# Altium schematic sheet PMON.SchDoc(PMON)
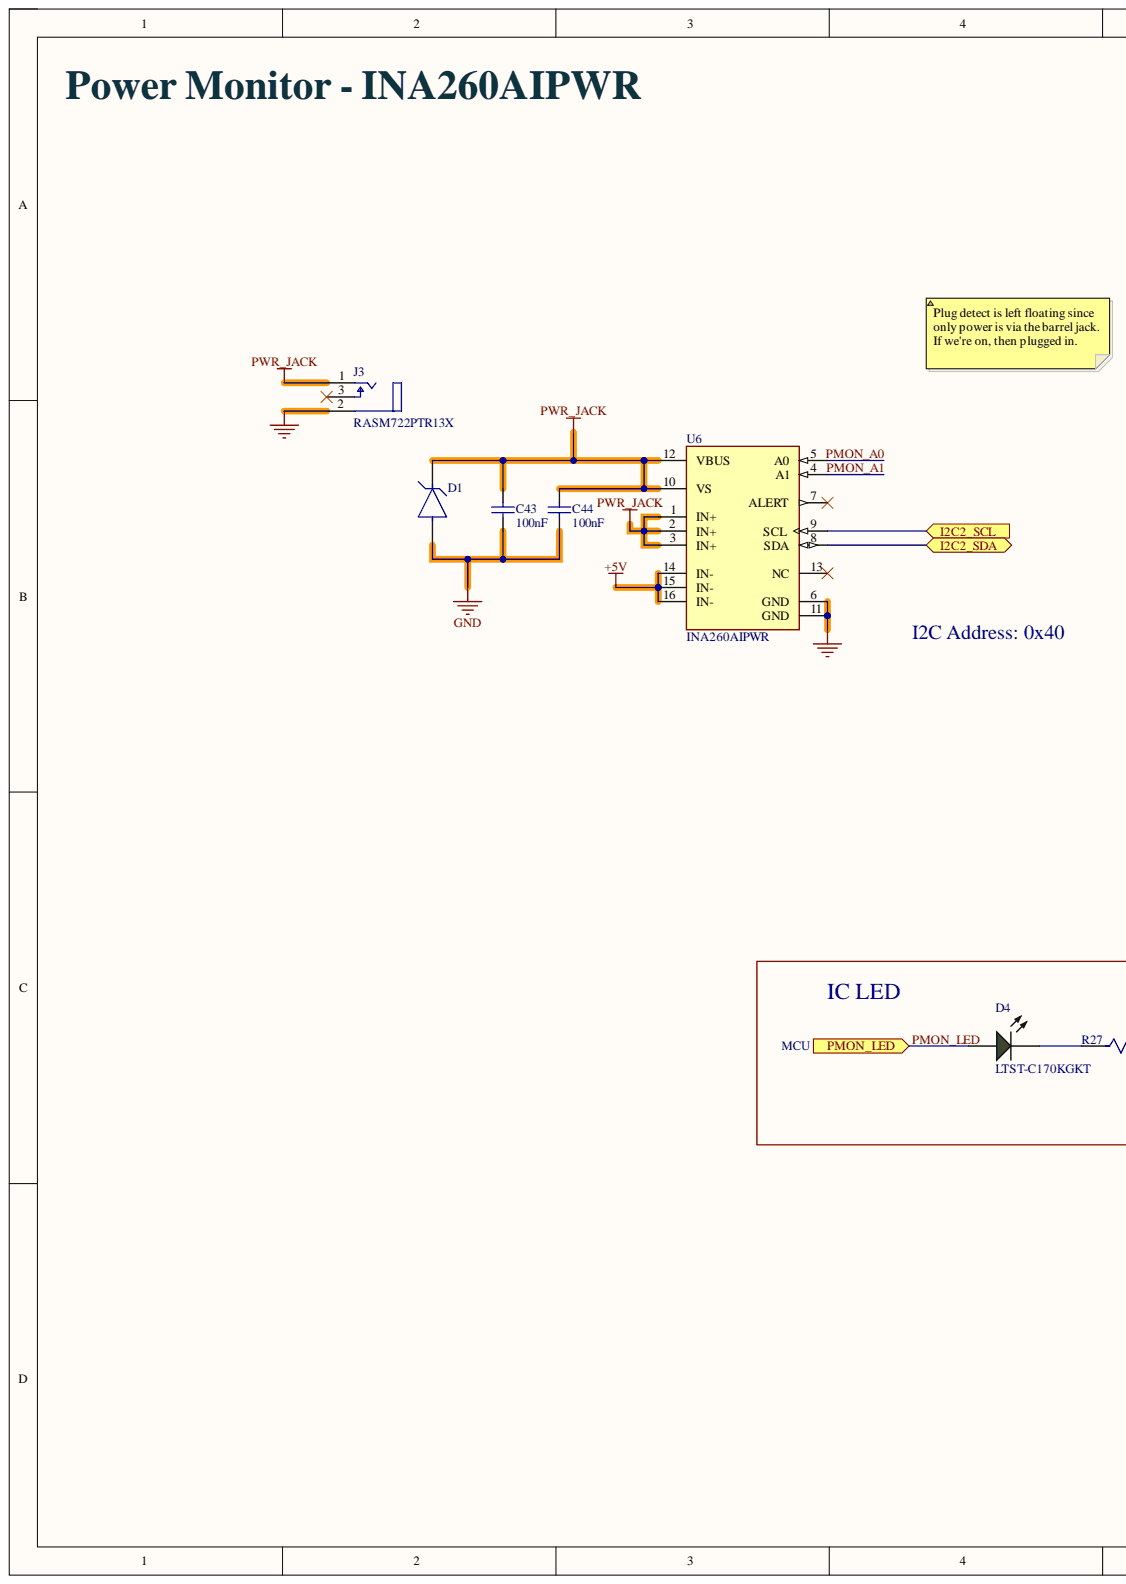
[diagram p0: PMON.SchDoc, left]
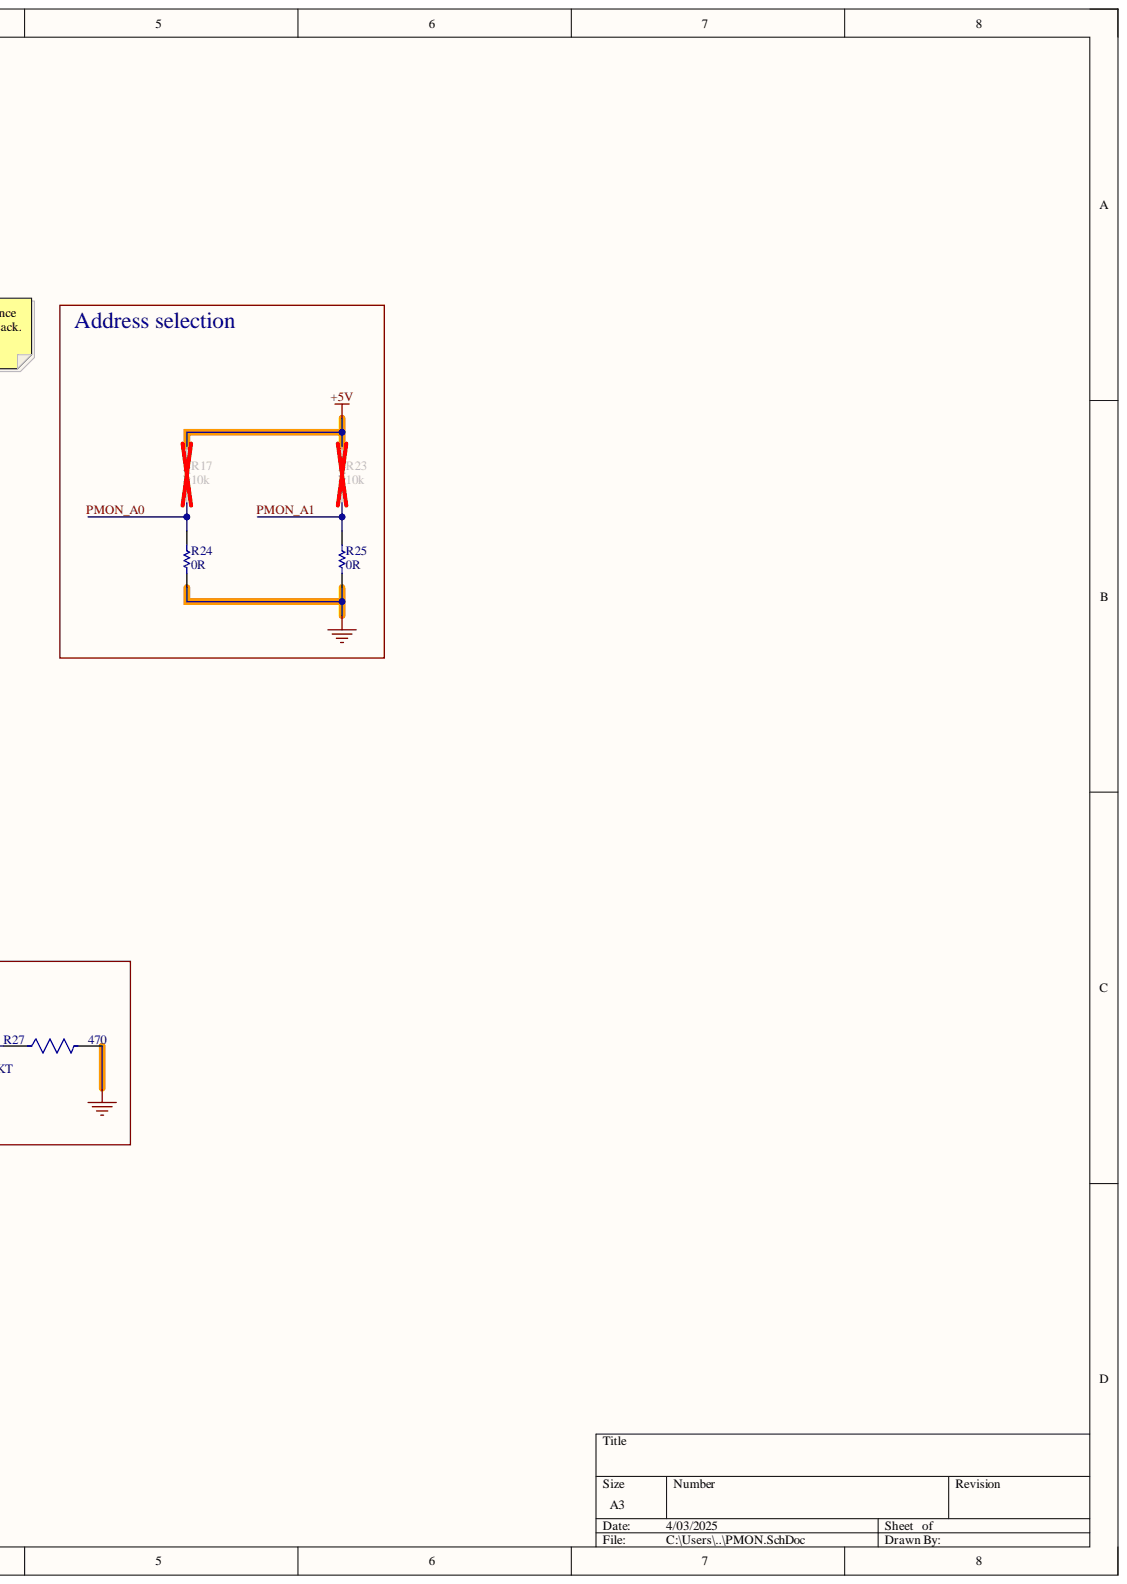
[diagram p1: PMON.SchDoc, right]

## Parts
C43  100nF  [CAPC1005X55X25ML05T10]
C44  100nF  [CAPC1005X55X25ML05T10]
D1  SMBJ5.0A-TR  [FP-SMB-MFG]  (value not drawn)
D4  LTST-C170KGKT  [LTST-LTST-C170KGKT-2_V]
J3  RASM722PTR13X  [FP-RASM722PTR13X-MFG]
R17  10k  [RESC1608X55X25ML10T15]
R23  10k  [RESC1608X55X25ML10T15]
R24  0R  [RESC1608X55X25ML10T15]
R25  0R  [RESC1608X55X25ML10T15]
R27  470  [FP-CPF0603-MFG]
U6  INA260AIPWR  [FP-PW0016A-MFG]

## Ports
I2C2_SCL, I2C2_SDA, PMON_LED

## Nets
+5V: R17.2 R23.2 U6.14(IN-) U6.15(IN-) U6.16(IN-)
GND: C43.1 C44.1 D1.2 J3.2 R24.1 R25.1 R27.2 U6.6(GND) U6.11(GND)
PWR_JACK: C43.2 C44.2 D1.1 J3.1 U6.1(IN+) U6.2(IN+) U6.3(IN+) U6.10(VS) U6.12(VBUS)
I2C2_SCL: U6.9(SCL) ; port I2C2_SCL
I2C2_SDA: U6.8(SDA) ; port I2C2_SDA
PMON_A0: R17.1 R24.2 U6.5(A0)
PMON_A1: R23.1 R25.2 U6.4(A1)
PMON_LED: D4.2 ; port PMON_LED
NetD4_1: D4.1 R27.1

Not connected: J3 3; U6 7(ALERT) 13(NC)

## Library data of the parts
C43 C44 = CMP-2008-04536-1: GRM155R60J104KA01D; Manufacturer: Murata; Component Kind: Standard; Library Name: Altium Content Vault; Part Number: GRM155R60J104KA01D
D1 = CMP-12225-000019-1: TVS DIODE 5V 13.4V SMB; Manufacturer: STMicroelectronics; Breakdown Voltage: 6.4V; Clamping Voltage: 14.4V; Direction: Unidirectional; Max Junction Temp: +150°C; Number Of Channels: 1; Peak Pulse Current: 275A; Peak Pulse Power: 600W; Standoff Height: 0.05mm; Automotive: No; Category: Diode; Component Kind: Standard; ComponentLink2Description: Datasheet; ComponentLink2URL: https://www.st.com/resource/en/datasheet/smbj.pdf; Device Class L1: Circuit Protection; Device Class L2: TVS Diodes; Device Class L3: unset; Digikey Description: TVS DIODE 5V 13.4V SMB; Height: 2.65mm; Lead Free: Yes; Library Name: Altium Content Vault; Manufacturer Part Number: SMBJ5.0A-TR; Mouser Description: SMBJ Series 600 W 5 V Uni Directional SMT TVS Diode - DO-214AA; Octopart: https://octopart.com/smbj5.0a-tr-stmicroelectronics-347079; Package: SMB; Reverse Standoff Voltage: 5V; RoHS: TRUE; Temperature: -55°C to +150°C; Temperature Range High: +150°C; Temperature Range Low: -55°C
D4 = CMP-2000-06620-1: Ultra Bright AlInGaP Chip LED, 75 mW, -55 to 85 degC, 2-Pin SMD (0805), RoHS, Tape and Reel; Manufacturer: Lite-On; Chip Material: AlGaInP; DELETED: Compliant; Dominant Wave Length: 571nm; Emitting Color: YellowGreen; Forward Current: 30mA; Forward Voltage: 2.4V; High Brightness: Yes; Lum Intensity: 35mcd; Number of  Elements: 1; Peak Wavelength: 574nm; Power Dissipation: 75W; Power Dissipation Max: 75mW; Product Depth (mm): 1.25mm; Product Height (mm): 1.1mm; Product Length (mm): 2mm; Rad Hardened: No; Reverse Voltage: 5V; Shape Type: Rectangular; Test Current (It): 20mA; Type: Uni-Color; Viewing Angle: 130deg; Component Kind: Standard; Datasheet URL: http://optoelectronics.liteon.com/upload/download/DS22-2000-073/S_110_LTST-C170KGKT.pdf; Datasheet Version: Rev. G, 06/2005; Forward Current Max: 30mA; Lens Dimensions: 1.4x1.25x0.6mm; Library Name: Altium Content Vault; Luminous Intensity: 35mcd; Main Category: ChipLED; Manufacturer URL: http://www.us.liteon.com/; Mounting: SurfaceMount; Mounting Technology: SurfaceMount; Operating Temp Range: -55Cto85C; Operating Temperature Classification: Industrial; Package Description: 2-Pin Surface Mounted Device, Body 2 x 1.25 mm; Package Reference: LTST-C170KGKT-2; Package Type: ChipLed; Packaging: TapeandReel; Pin Count: 2
J3 = CMP-47995-000002-1: CONN PWR JACK 2.1X5.5MM SOLDER; Current Rating: 5A; Gender: Male; Shielding: Unshielded; Case/Package: SMD/SMT; Color: Black; Component Kind: Standard; Connector Type: Jack; Contact Material: Copper Alloy; Contact Plating: Tin; Housing Color: Black; Housing Material: Thermoplastic; Library Name: Altium Content Vault; Mount: Surface Mount; Package Quantity: 120; Termination: Solder
R17 R23 = CMP-2000-05022-1: Resistor, 10 KOhm, +/- 5%, 100 mW, -55 to 155 degC, 0603 (1608 Metric), RoHS, Tape and Reel; Manufacturer: Yageo; Power Rating: 100mW; Resistance: 10kOhms; Temperature Coefficient: 100PPM/C; Voltage Rating: 50V; Case Code - in: 0603; Case Code - mm: 1608; Component Kind: Standard; Datasheet URL: http://www.farnell.com/datasheets/443971.pdf; Datasheet Version: Rev. 4, 04/2009; Library Name: Altium Content Vault; Manufacturer URL: http://www.yageo.com/; Operating Temperature Range: -55Cto+155C; Package Description: 2-Pin Surface Mount Device, Body 1.6 x 0.8 mm; Package Reference: 603; Packaging: Reel; Product: ThickFilmResistorsGeneralPurpose; Series: RC; Termination Style: SMD/SMT; Tolerance: 5%
R24 R25 = CMP-2100-03667-1: Chip Resistor, 0 Ohm, +/- 5%, 0.1 W, -55 to 155 degC, 0603 (1608 Metric), RoHS, Tape and Reel; Manufacturer: Yageo; Power Rating: 100mW; Resistance: 0mOhm; Temperature Coefficient: 200ppm/degreesC; Voltage Rating: 50V; Case Code (Imperial): 0603; Case Code (Metric): 1608; Case/Package: 0603; Component Kind: Standard; Composition: ThickFilm; Contact Plating: Tin; Datasheet URL: http://www.yageo.com/NewPortal/yageodocoutput?fileName=/pdf/R-Chip/PYu-RC_Group_51_RoHS_L_5.pdf; Datasheet Version: Rev. 5, 10/2016; Depth: 0.8mm; Height: 0.45mm; Lead Free: LeadFree; Length: 1.6mm; Library Name: Altium Content Vault; Manufacturer URL: http://www.yageo.com/; Max Operating Temperature: 155degC; Military Standard: Not; Min Operating Temperature: -55degC; Number of Pins: 2; Package Description: 2-Pin Surface Mount Device, Body 1.6 x 0.8 mm; Package Quantity: 5000; Package Reference: 0603; Packaging: TapeandReel; Radiation Hardening: No; REACH SVHC: NoSVHC; RoHSCompliant: true; Termination: SMD/SMT; Tolerance: 5%; Voltage Rating (DC): 50V; Width: 0.8mm
R27 = CMP-03211-004765-1: RES SMD 470Ω 0.1% 1/16W 0603    CPF0603B470RE; Manufacturer: TE Connectivity; Power Rating: 0.063W; Temperature Coefficient: 25ppm/°C; Voltage Rating: 50V; Automotive: No; Category: Res; Component Kind: Standard; ComponentLink2Description: Datasheet; ComponentLink2URL: https://www.te.com/commerce/DocumentDelivery/DDEController?Action=showdoc&DocId=Data+Sheet%7F1773200%7FM%7Fpdf%7FEnglish%7FENG_DS_1773200_M.pdf%7F3-1676481-2; Composition: Thin Film; Device Class L1: Passive Components; Device Class L2: Resistors; Device Class L3: Chip SMD Resistors; Height: 0.55mm; Ipc Land Pattern Name: RESC15580X45; Lead Free: Yes; Library Name: Altium Content Vault; Manufacturer Part Number: CPF0603B470RE; Octopart: https://octopart.com/cpf0603b470re-te+connectivity-23376607; octopart_url: https://octopart.com/cpf0603b470re-te+connectivity-23376607; Package: CPF0603; packaging: 5k Reel; Resistance: 470Ω; RoHS: TRUE; Series: CPF; Temperature: -55°C to +155°C; Temperature Range High: +155°C; Temperature Range Low: -55°C; Tolerance: 0.1%; Value: 470Ω
U6 = CMP-04910-000015-1: IC MONITOR PWR/CURR 16TSSOP; Manufacturer: Texas Instruments; Max Junction Temp: +150°C; Max Supply Voltage: 5.5V; Min Supply Voltage: 2.7V; Nominal Supply Current: 310uA; Output Current: 10mA; Standoff Height: 0.05mm; Ambient Temperature Range High: +125°C; Ambient Temperature Range Low: -40°C; Automotive: No; Category: IC; Component Kind: Standard; ComponentLink2Description: Datasheet; ComponentLink2URL: http://www.ti.com/lit/ds/symlink/ina260.pdf; Device Class L1: Integrated Circuits (ICs); Device Class L2: Power Management ICs; Device Class L3: unset; Digikey Description: IC MONITOR PWR/CURR 16TSSOP; Height: 1.2mm; Lead Free: Yes; Library Name: Altium Content Vault; Manufacturer Part Number: INA260AIPWR; Octopart: https://octopart.com/ina260aipwr-texas+instruments-75199489; Package: PW0016A; RoHS: TRUE; Temperature: -40°C to +125°C; Temperature Range High: +125°C; Temperature Range Low: -40°C
